# Altium schematic sheet Sensing_Control_3phase_sheet1.SchDoc
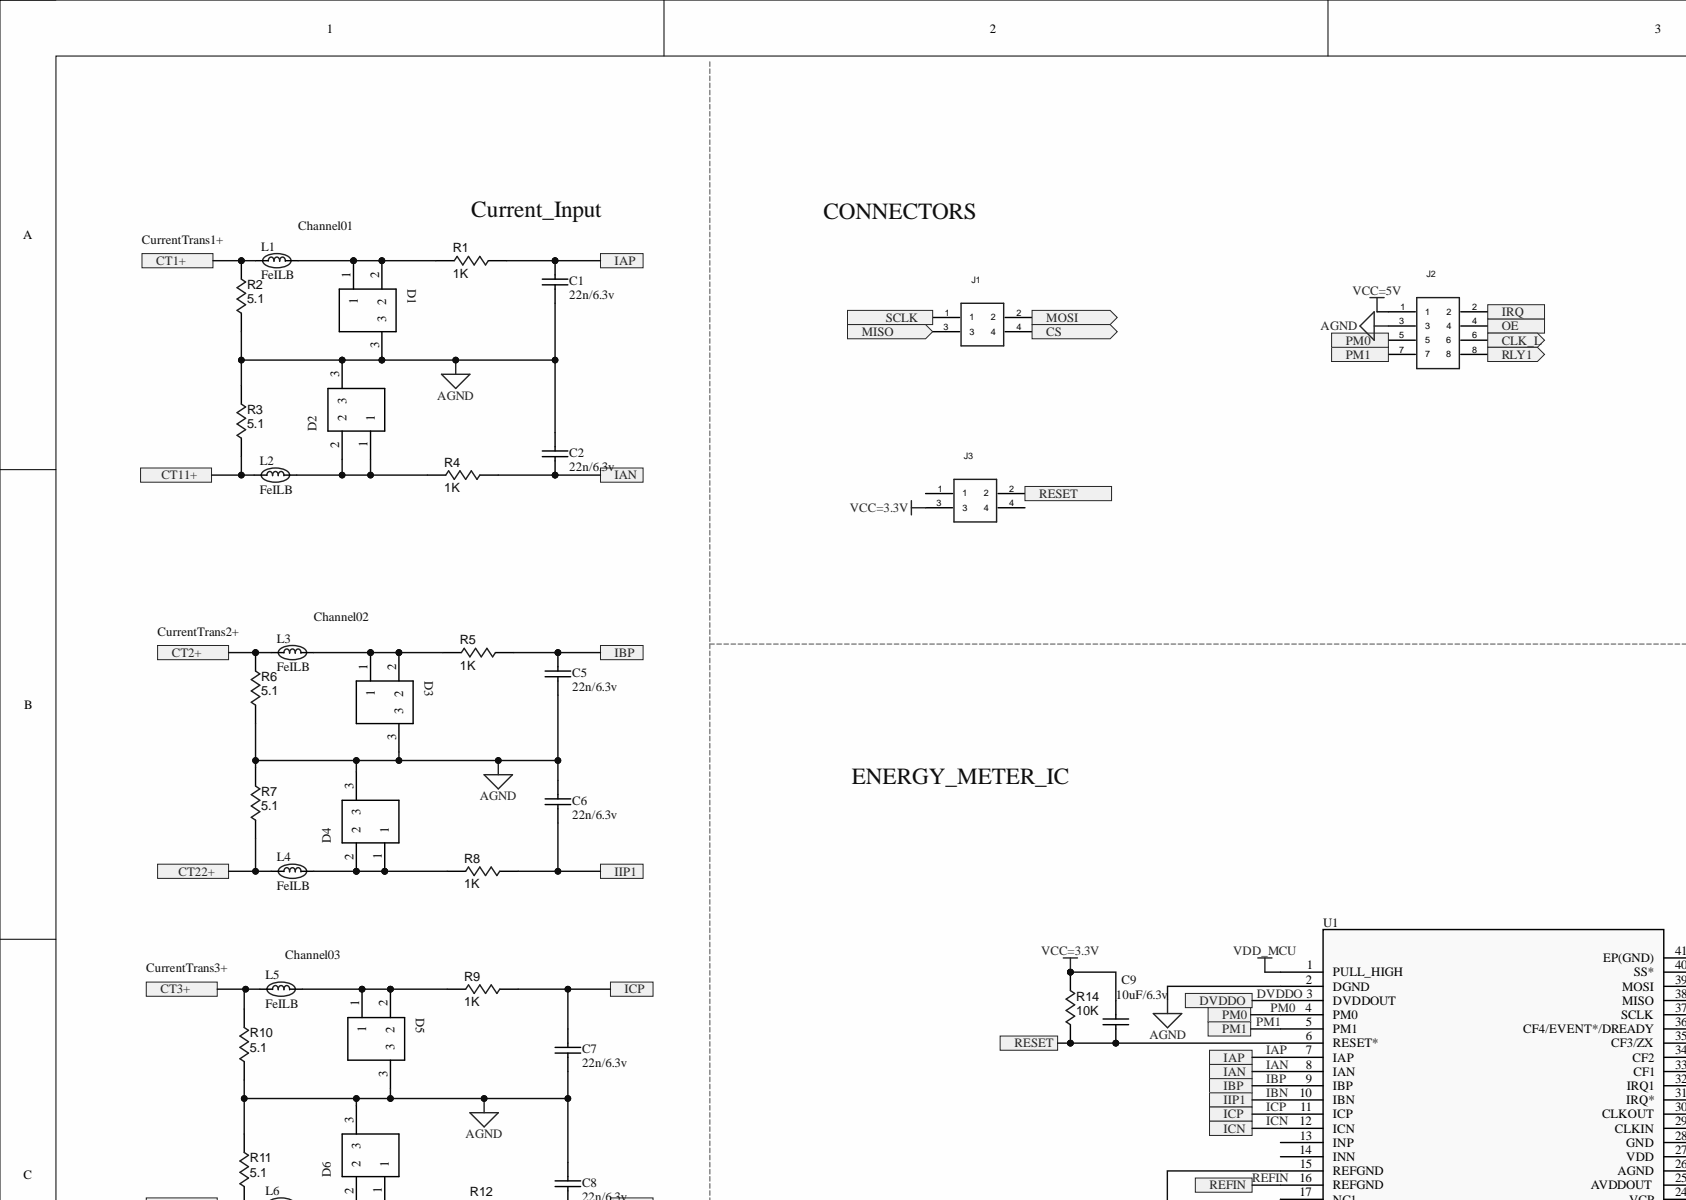
[diagram p0: Sensing_Control_3phase_sheet1.SchDoc, top left]
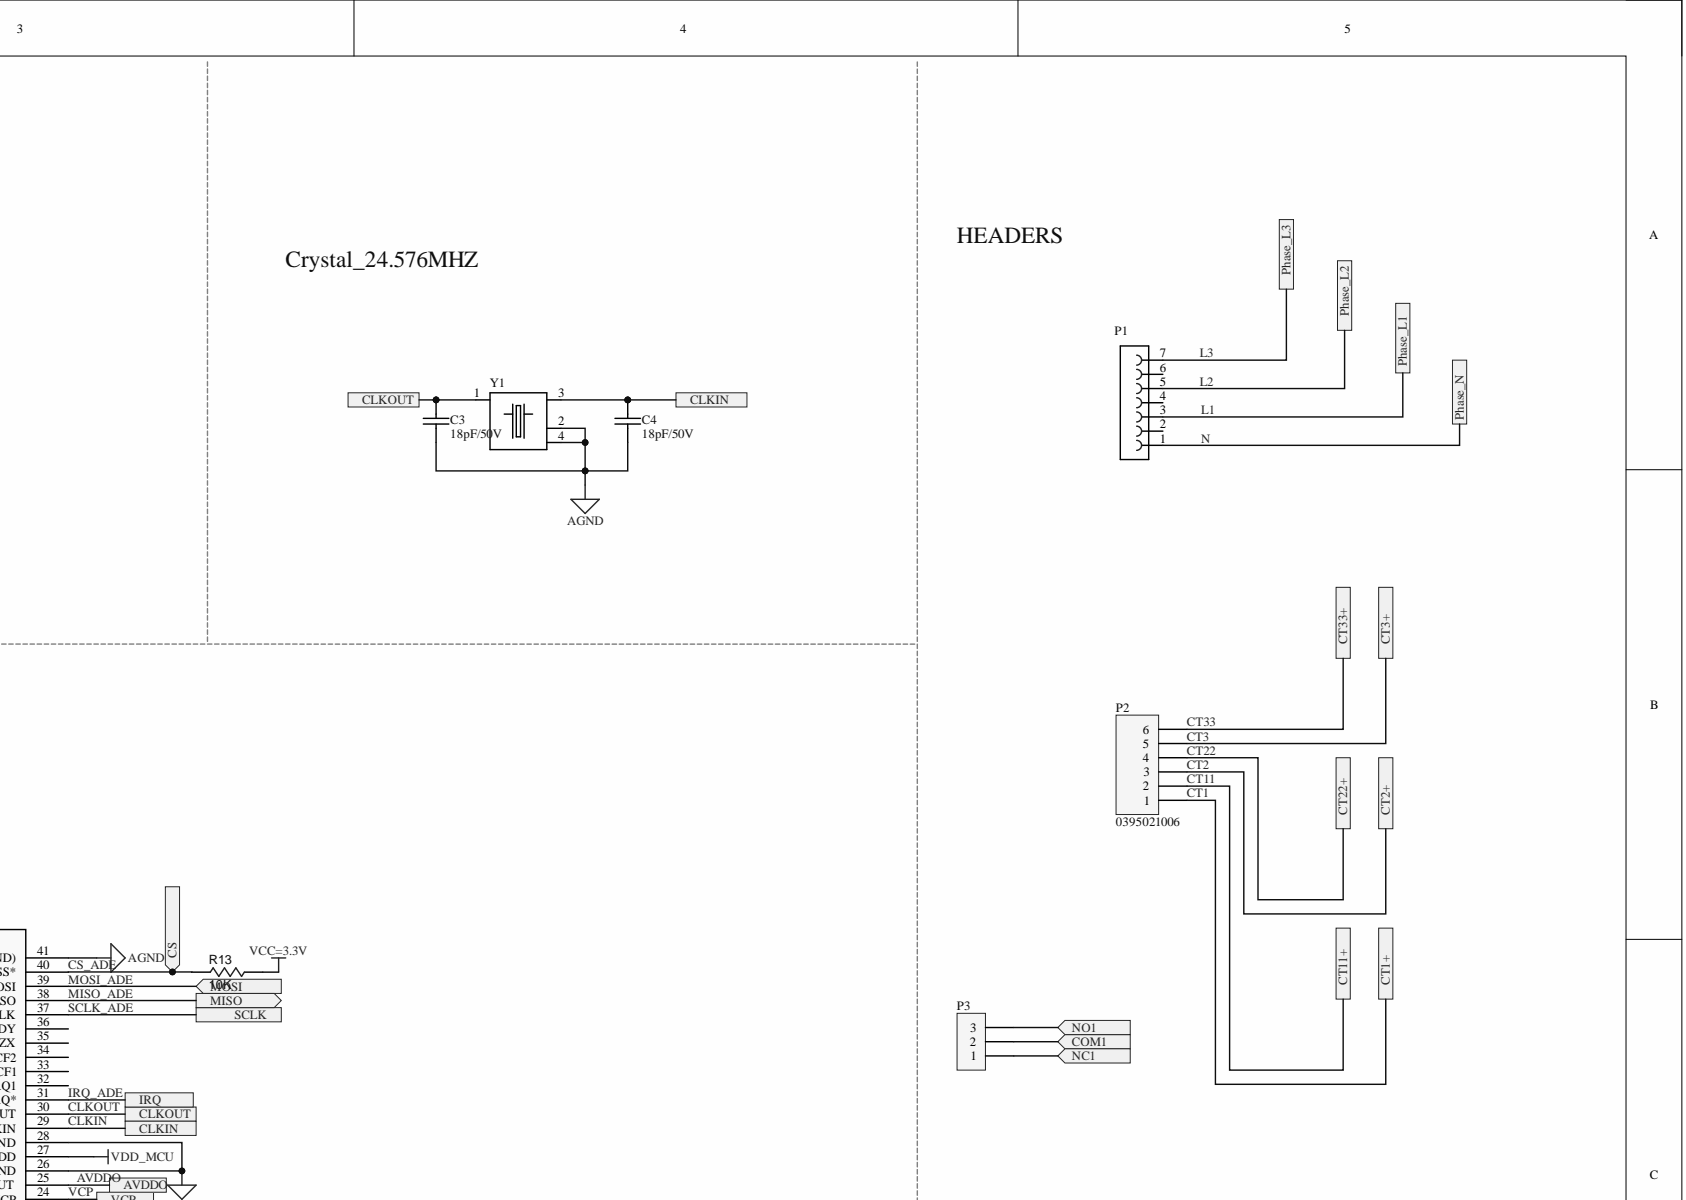
[diagram p1: Sensing_Control_3phase_sheet1.SchDoc, top right]
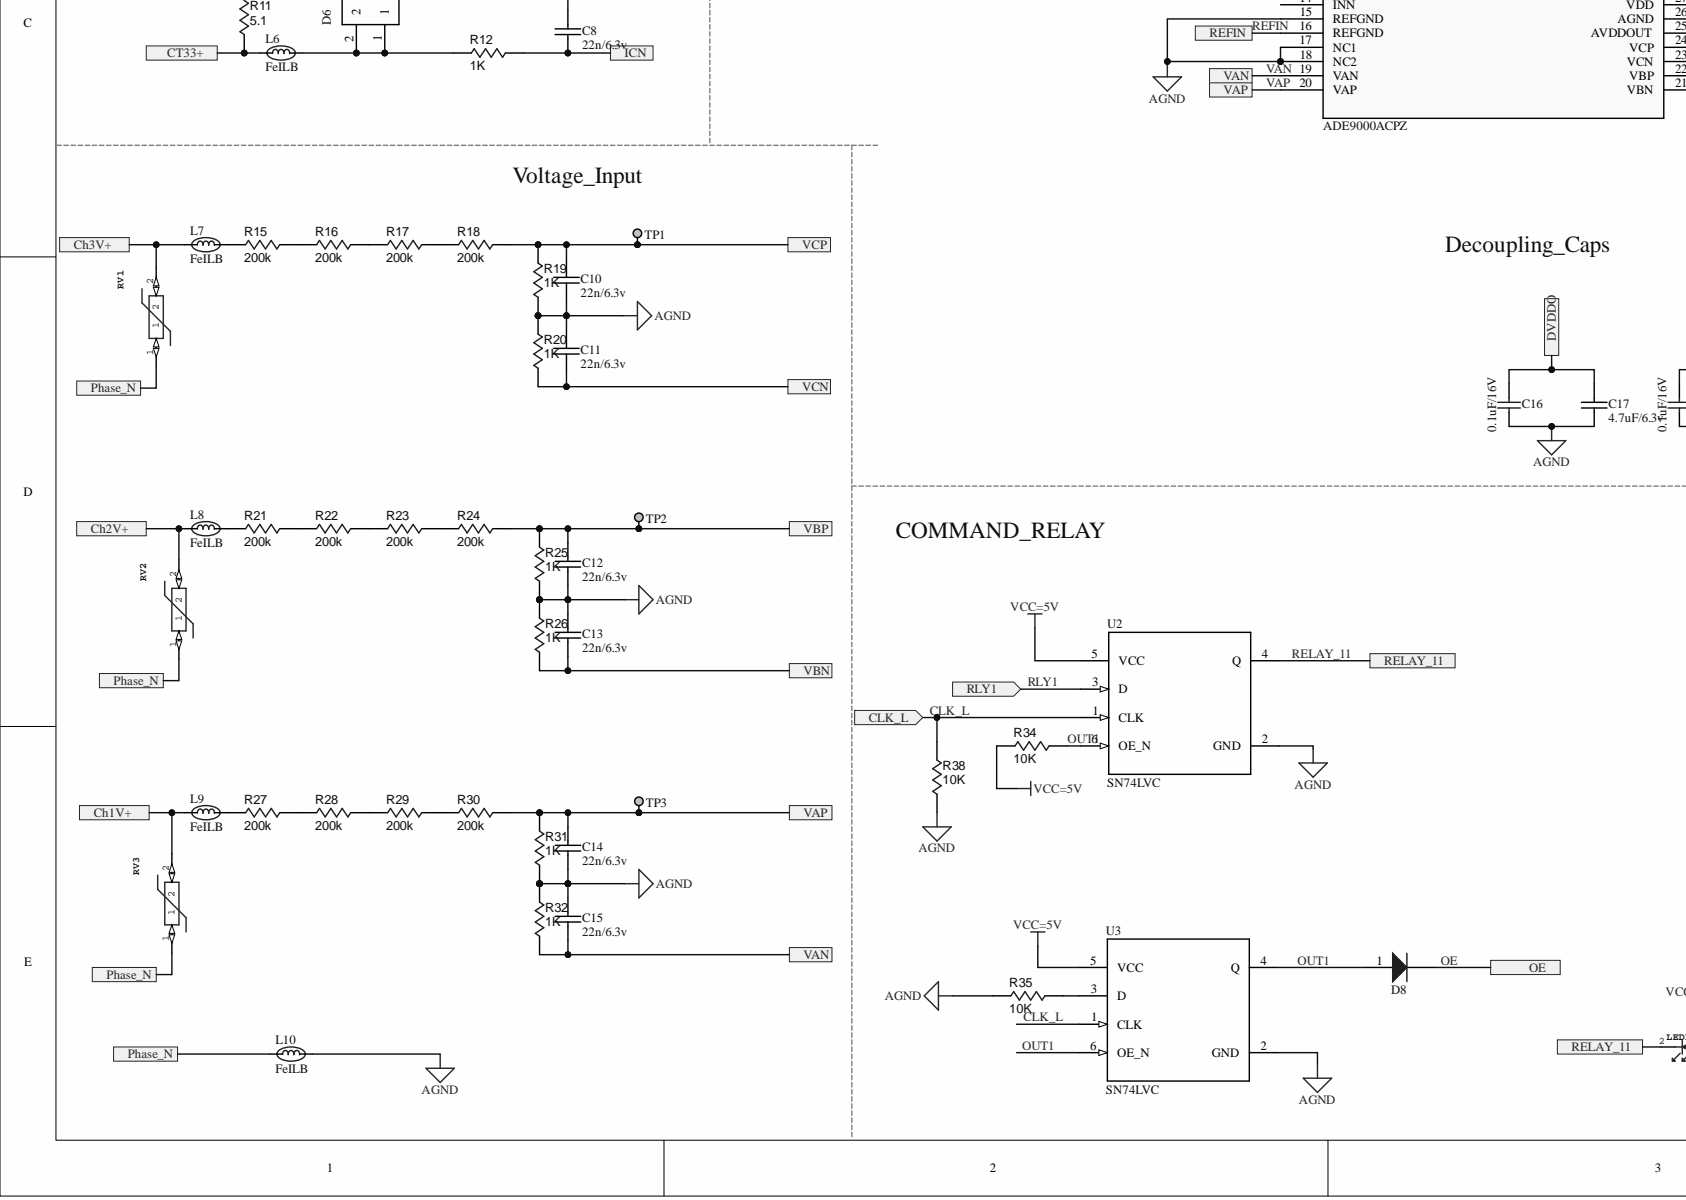
[diagram p2: Sensing_Control_3phase_sheet1.SchDoc, bottom left]
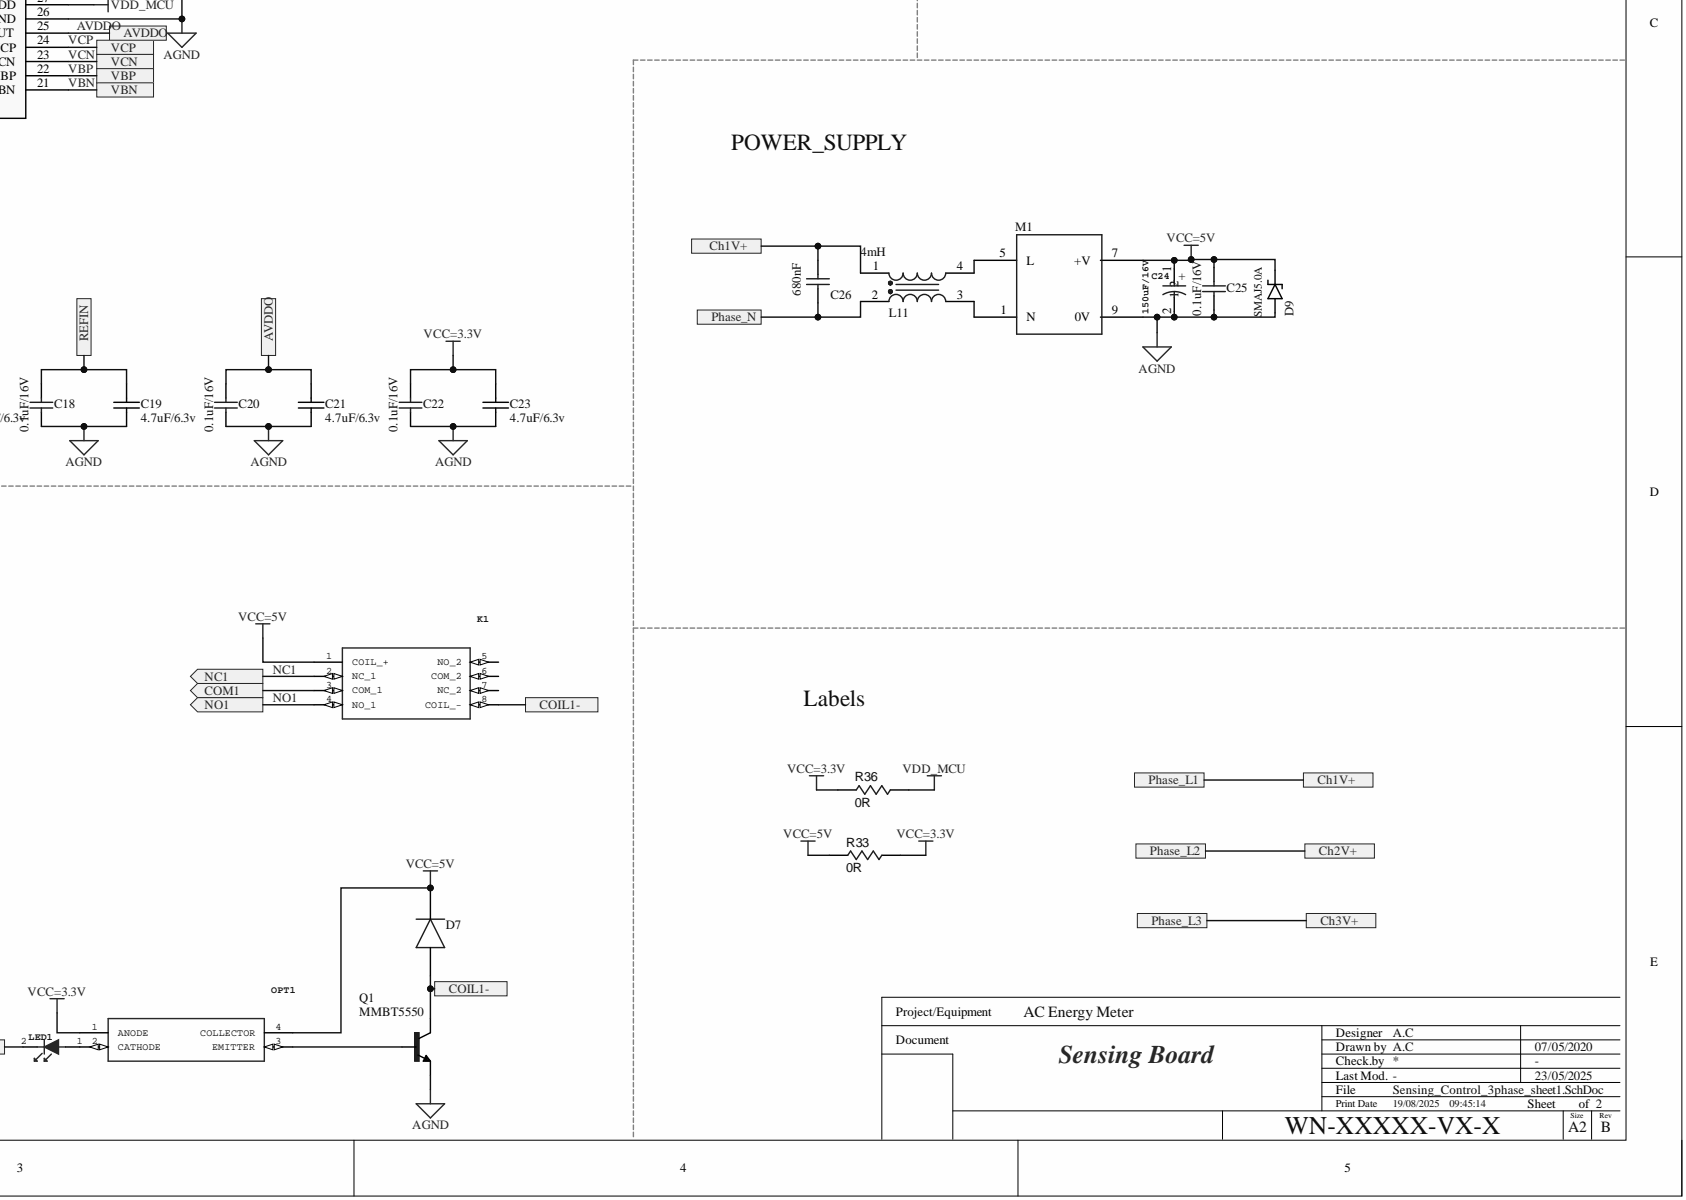
[diagram p3: Sensing_Control_3phase_sheet1.SchDoc, bottom right]

## Parts
C1  22n/6.3v  [0603C-TBD]
C2  22n/6.3v  [0603C-TBD]
C3  18pF/50V  [0603C-TBD]
C4  18pF/50V  [0603C-TBD]
C5  22n/6.3v  [0603C-TBD]
C6  22n/6.3v  [0603C-TBD]
C7  22n/6.3v  [0603C-TBD]
C8  22n/6.3v  [0603C-TBD]
C9  10uF/6.3v  [0603C-TBD]
C10  22n/6.3v  [0603C-TBD]
C11  22n/6.3v  [0603C-TBD]
C12  22n/6.3v  [0603C-TBD]
C13  22n/6.3v  [0603C-TBD]
C14  22n/6.3v  [0603C-TBD]
C15  22n/6.3v  [0603C-TBD]
C16  0.1uF/16V  [0603C-TBD]
C17  4.7uF/6.3v  [0603C-TBD]
C18  0.1uF/16V  [0603C-TBD]
C19  4.7uF/6.3v  [0603C-TBD]
C20  0.1uF/16V  [0603C-TBD]
C21  4.7uF/6.3v  [0603C-TBD]
C22  0.1uF/16V  [0603C-TBD]
C23  4.7uF/6.3v  [0603C-TBD]
C24  150uF/16V  [CAPAE660X800N]
C25  0.1uF/16V  [0603C-TBD]
C26  680nF  [WCAP-FTX2_L18W10H16_CS]
D1  BAV99W-7-F  [BAV99W-7-F]  (value not drawn)
D2  BAV99W-7-F  [BAV99W-7-F]  (value not drawn)
D3  BAV99W-7-F  [BAV99W-7-F]  (value not drawn)
D4  BAV99W-7-F  [BAV99W-7-F]  (value not drawn)
D5  BAV99W-7-F  [BAV99W-7-F]  (value not drawn)
D6  BAV99W-7-F  [BAV99W-7-F]  (value not drawn)
D7  1N4148W-13-F  [SOD3716X135N]  (value not drawn)
D8  TS4148C RZG  [LEDM1608X80]  (value not drawn)
D9  SMAJ5.0A  [DIOM5436X245N]
J1  SFMC-102-X1-XX-D  [SFMC-102-X1-XX-D - 570]  (value not drawn)
J2  SFMC-104-X1-XX-D  [SFMC-104-X1-XX-D - 570]  (value not drawn)
J3  SFMC-102-X1-XX-D  [SFMC-102-X1-XX-D - 570]  (value not drawn)
K1  Low Signal Relays - PCBSource:  Datasheet  [UD25NUN]  (value not drawn)
L1  FeILB  [0603C]
L2  FeILB  [0603C]
L3  FeILB  [0603C]
L4  FeILB  [FerriteBead-0603]
L5  FeILB  [FerriteBead-0603]
L6  FeILB  [FerriteBead-0603]
L7  FeILB  [FerriteBead-0603]
L8  FeILB  [FerriteBead-0603]
L9  FeILB  [FerriteBead-0603]
L10  FeILB  [FerriteBead-0603]
L11  4mH  [WE-CMB_Type XS_L15.8W7.8H18]
LED1  APHD1608LCGCK  [LED-1608]  (value not drawn)
M1  Myrra47152  [Myrra 47121]  (value not drawn)
OPT1  HCPL-817-000E  [DIP762W60P254L460H420Q4N]  (value not drawn)
P1  0395021007  [Molex_395021007 1X7]  (value not drawn)
P2  0395021006  [Molex_0395021006 1X6_2240X920X]
P3  0395021003  [Molex_0395021003 1X3_1190X920X]  (value not drawn)
Q1  MMBT5550  [SOT23]
R1  1K  [0603C-TBD]
R2  5.1  [0603C-TBD]
R3  5.1  [0603C-TBD]
R4  1K  [0603C-TBD]
R5  1K  [0603C-TBD]
R6  5.1  [0603C-TBD]
R7  5.1  [0603C-TBD]
R8  1K  [0603C-TBD]
R9  1K  [0603C-TBD]
R10  5.1  [0603C-TBD]
R11  5.1  [0603C-TBD]
R12  1K  [0603C-TBD]
R13  10K  [0603C-TBD]
R14  10K  [0603C-TBD]
R15  200k  [RES-5025-2010]
R16  200k  [RES-5025-2010]
R17  200k  [RES-5025-2010]
R18  200k  [RES-5025-2010]
R19  1K  [0603C-TBD]
R20  1K  [0603C-TBD]
R21  200k  [RES-5025-2010]
R22  200k  [RES-5025-2010]
R23  200k  [RES-5025-2010]
R24  200k  [RES-5025-2010]
R25  1K  [0603C-TBD]
R26  1K  [0603C-TBD]
R27  200k  [RES-5025-2010]
R28  200k  [RES-5025-2010]
R29  200k  [RES-5025-2010]
R30  200k  [RES-5025-2010]
R31  1K  [0603C-TBD]
R32  1K  [0603C-TBD]
R33  0R  [0603C-TBD]
R34  10K  [0603C-TBD]
R35  10K  [0603C-TBD]
R36  0R  [0603C-TBD]
R38  10K  [0603C-TBD]
RV1  EPCOS - B72207S0271K101 - VARISTOR,21.0J,275VAC   Source:  Datasheet  [B72207S0271K101]  (value not drawn)
RV2  EPCOS - B72207S0271K101 - VARISTOR,21.0J,275VAC   Source:  Datasheet  [B72207S0271K101]  (value not drawn)
RV3  EPCOS - B72207S0271K101 - VARISTOR,21.0J,275VAC   Source:  Datasheet  [B72207S0271K101]  (value not drawn)
TP1  TESTPOINT  [TESTPOINT]  (value not drawn)
TP2  TESTPOINT  [TESTPOINT]  (value not drawn)
TP3  TESTPOINT  [TESTPOINT]  (value not drawn)
U1  ADE9000ACPZ  [QFN50P600X600X100-41N]
U2  SN74LVC  [DCK0006A_N]
U3  SN74LVC  [DCK0006A_N]
Y1  ECS-245.7-12-33Q-JES-TR  [OSCCC320X250X80N]  (value not drawn)

## Ports
AVDDO, Ch1V+, Ch2V+, Ch3V+, CLK_L, CLKIN, CLKOUT, COIL1-, COM1, CS, CT1+, CT2+, CT3+, CT11+, CT22+, CT33+, DVDDO, IAN, IAP, IBP, ICN, ICP, IIP1, IRQ, MISO, MOSI, NC1, NO1, OE, Phase_L1, Phase_L2, Phase_L3, Phase_N, PM0, PM1, REFIN, RELAY_11, RESET, RLY1, SCLK, VAN, VAP, VBN, VBP, VCN, VCP

## Nets
AGND: C1.2 C2.1 C3.2 C4.2 C5.2 C6.1 C7.2 C8.1 C10.2 C11.1 C12.2 C13.1 C14.2 C15.1 C16.2 C17.2 C18.2 C19.2 C20.2 C21.2 C22.2 C23.2 C24.2 C25.2 D1.3 D2.3 D3.3 D4.3 D5.3 D6.3 D9.A J2.3 L10.2 M1.9 Q1.2 R2.1 R3.2 R6.1 R7.2 R10.1 R11.2 R19.1 R20.2 R25.1 R26.2 R31.1 R32.2 R35.2 R38.2 U1.2 U1.15 U1.17 U1.18 U1.26 U1.28 U1.41 U2.2 U3.2 Y1.2 Y1.4
VCC=3.3V: C9.1 C22.1 C23.1 J3.3 OPT1.1 R13.2 R14.2 R33.2 R36.1
VCC=5V: C24.1 C25.1 D7.1 D9.C J2.1 K1.1 M1.7 OPT1.4 R33.1 R34.2 U2.5 U3.5
VDD_MCU: R36.2 U1.1 U1.27
AVDDO: C20.1 C21.1 U1.25 ; port AVDDO
CLK_L: J2.6 R38.1 U2.1 U3.1 ; port CLK_L
CLKIN: C4.1 U1.29 Y1.3 ; port CLKIN
CLKOUT: C3.1 U1.30 Y1.1 ; port CLKOUT
COIL1-: D7.2 K1.8 Q1.3 ; port COIL1-
COM1: K1.3 P3.2 ; port COM1
CS_ADE: J1.4 R13.1 U1.40 ; port CS
CT1: L1.1 P2.1 R2.2 ; port CT1+
CT2: L3.1 P2.3 R6.2 ; port CT2+
CT3: L5.1 P2.5 R10.2 ; port CT3+
CT11: L2.1 P2.2 R3.1 ; port CT11+
CT22: L4.1 P2.4 R7.1 ; port CT22+
CT33: L6.1 P2.6 R11.1 ; port CT33+
DVDDO: C16.1 C17.1 U1.3 ; port DVDDO
IAN: C2.2 R4.2 U1.8 ; port IAN
IAP: C1.1 R1.2 U1.7 ; port IAP
IBN: C6.2 R8.2 U1.10 ; port IIP1
IBP: C5.1 R5.2 U1.9 ; port IBP
ICN: C8.2 R12.2 U1.12 ; port ICN
ICP: C7.1 R9.2 U1.11 ; port ICP
IRQ_ADE: J2.2 U1.31 ; port IRQ
L1: C26.2 L9.1 L11.1 P1.3 RV3.2 ; port Ch1V+, port Phase_L1
L2: L8.1 P1.5 RV2.2 ; port Ch2V+, port Phase_L2
L3: L7.1 P1.7 RV1.2 ; port Ch3V+, port Phase_L3
MISO_ADE: J1.3 U1.38 ; port MISO
MOSI_ADE: J1.2 U1.39 ; port MOSI
N: C26.1 L10.1 L11.2 P1.1 RV1.1 RV2.1 RV3.1 ; port Phase_N
NC1: K1.2 P3.1 ; port NC1
NO1: K1.4 P3.3 ; port NO1
OE: D8.2 J2.4 ; port OE
OUT1: D8.1 R34.1 U2.6 U3.4 U3.6
PM0: J2.5 U1.4 ; port PM0
PM1: J2.7 U1.5 ; port PM1
REFIN: C18.1 C19.1 U1.16 ; port REFIN
RELAY_11: LED1.2 U2.4 ; port RELAY_11
RESET: C9.2 J3.2 R14.1 U1.6 ; port RESET
RLY1: J2.8 U2.3 ; port RLY1
SCLK_ADE: J1.1 U1.37 ; port SCLK
VAN: C15.2 R32.1 U1.19 ; port VAN
VAP: C14.1 R30.2 R31.2 TP3.1 U1.20 ; port VAP
VBN: C13.2 R26.1 U1.21 ; port VBN
VBP: C12.1 R24.2 R25.2 TP2.1 U1.22 ; port VBP
VCN: C11.2 R20.1 U1.23 ; port VCN
VCP: C10.1 R18.2 R19.2 TP1.1 U1.24 ; port VCP
NetD1_1: D1.1 D1.2 L1.2 R1.1
NetD2_1: D2.1 D2.2 L2.2 R4.1
NetD3_1: D3.1 D3.2 L3.2 R5.1
NetD4_1: D4.1 D4.2 L4.2 R8.1
NetD5_1: D5.1 D5.2 L5.2 R9.1
NetD6_1: D6.1 D6.2 L6.2 R12.1
NetJ3_1: J3.1
NetJ3_4: J3.4
NetK1_5: K1.5
NetK1_6: K1.6
NetK1_7: K1.7
NetL7_2: L7.2 R15.1
NetL8_2: L8.2 R21.1
NetL9_2: L9.2 R27.1
NetL11_3: L11.3 M1.1
NetL11_4: L11.4 M1.5
NetLED1_1: LED1.1 OPT1.2
NetOPT1_3: OPT1.3 Q1.1
NetP1_2: P1.2
NetP1_4: P1.4
NetP1_6: P1.6
NetR15_2: R15.2 R16.1
NetR16_2: R16.2 R17.1
NetR17_2: R17.2 R18.1
NetR21_2: R21.2 R22.1
NetR22_2: R22.2 R23.1
NetR23_2: R23.2 R24.1
NetR27_2: R27.2 R28.1
NetR28_2: R28.2 R29.1
NetR29_2: R29.2 R30.1
NetR35_1: R35.1 U3.3
NetU1_13: U1.13
NetU1_14: U1.14
NetU1_32: U1.32
NetU1_33: U1.33
NetU1_34: U1.34
NetU1_35: U1.35
NetU1_36: U1.36

## Library data of the parts
C1 C2 C5-C8 C10-C15 = 0603C: CAPACITOR CERAMIC 0603 0.022u , 6.3V, X7R.; Manufacturer: Murata; Manufacturer Part Number: GRM188R70J223KA01D; Capacitance: 22nF; Dielectric: X7R; Tolerance: 10%; Category: Ceramic Capacitors; Component Kind: Standard; Library Name: Schematic_library.SchLib; Supplier 1: Digi-Key; Supplier Part Number 1: 490-14809-1-ND; Voltage Rating: 6.3V
C3 C4 = 0603C: CAPACITOR 0603 CERAMIC  50V, 10%; Manufacturer: KEMET; Manufacturer Part Number: C0603C180K5GACTU; Capacitance: 18pF; Case Code (Metric): 1608; Component Kind: Standard; Library Name: Schematic_library.SchLib; Supplier 1: Digi-Key; Supplier Part Number 1: 399-7866-1-ND; Tolerance: 10%; Voltage Rating: 50V
C9 = 0603C: CAPACITOR 0603 CERAMIC  6.3V, X5R, 20%; Manufacturer: Samsung; Manufacturer Part Number: CL10A106MQ8NNNC; Capacitance: 10uF; Case Code (Metric): 1608; Category: Ceramic Capacitors; Component Kind: Standard; Dielectric: X5R; Library Name: Schematic_library.SchLib; Supplier 1: Digi-Key; Supplier Part Number 1: 1276-1119-1-ND; Tolerance: 20%; Voltage: 6.3V
C16 C18 C20 C22 C25 = Cap: CAPACITOR 0603 CERAMIC; Manufacturer: Samsung; Manufacturer Part Number: CL10F104ZO8NNNC; Capacitance: 100nF; Value: 100nF; Voltage Rating: 16V; Case Code (Metric): 1608; Category: Ceramic Capacitors; Component Kind: Standard; Library Name: Schematic_library.SchLib; Revision: July-2002: Re-released for DXP Platform.; Signal Integrity: Cap; Simulation: CAP; Supplier 1: Digi-Key; Supplier Part Number 1: 1276-1258-1-ND
C17 C19 C21 C23 = 0603C: CAPACITOR 0603 CERAMIC  6.3V, 20%; Manufacturer: Samsung; Manufacturer Part Number: CL10A475KQ8NNNC; Capacitance: 4.7uF; Dielectric: X5R; Case Code (Metric): 1608; Category: Ceramic Capacitors; Component Kind: Standard; Library Name: Schematic_library.SchLib; Supplier 1: Digi-Key; Supplier Part Number 1: 1276-1045-1-ND; Tolerance: 20%; Voltage: 6.3V
C24 = Cap_Pol_UUD1C151MCL1GS: Condensateurs électrolytiques aluminium 16V 150µF Radial, boîtier métallique - SMD 2000 heures à 105°C; Manufacturer_Name: Panasonic; Allied_Number: 70068101; DeviceSetName: EEEFK1C151XP; GateName_1: G$1; Allied_Price-Stock: https://www.alliedelec.com/panasonic-eeefk1c151xp/70068101/; Component Kind: Standard; ComponentLink1Description: ref2; ComponentLink1URL: https://www.digikey.fr/product-detail/fr/w-rth-elektronik/865060345006/732-8523-1-ND/5728480; ComponentLink2Description: ref3; ComponentLink2URL: https://www.digikey.fr/product-detail/fr/nichicon/UWZ1C151MCL1GS/493-9926-1-ND/3963223; Height: 8mm; Library Name: Schematic_library.SchLib; LibraryName: EEEFK1C151XP; Manufacturer_Part_Number: EEEFK1C151XP; Package: CAPAE660X800N; RS_Part_Number: 7478920; RS_Price-Stock: https://uk.rs-online.com/web/p/products/7478920; Supplier 1: Digi-Key; Supplier Part Number 1: 493-2263-1-ND; SymbolName_1: EEEFK1C151XP
C26 = 890324025045CS: WCAP-FTX2 Film Capacitor, THT, L18 x W10 x H16mm, 680nF, 275V   Aletrnate Part R46KI368045P1M; Manufacturer: Wurth Elektronik; Capacitance Tolerance: +/-10%; Dissipation Factor @1kHz: 0.1%; Dissipation Factor @10kHz: 0.7%; Insulation Resistance: 10000Ω x F; Pitch: 15mm; Rate of Voltage Rise: 230V/µs; Technical Reference: MX2P015684K275ACPP45004; Capacitance: 680nF; Category: Film Capacitors; Component Kind: Standard; ComponentLink1Description: Website Link; ComponentLink1URL: https://www.we-online.com/redexpert/article/890324025045CS?ad; ComponentLink2Description: Datasheet Link; ComponentLink2URL: https://www.we-online.com/redexpert/spec/890324025045CS?ad; ComponentLink3Description: http://www.mouser.com/ds/2/212/KEM_F3094_R46_X2_275_110C_MIN-1104429.pdf?src-supplier=Mouser; ComponentLink3URL: http://www.mouser.com/ds/2/212/KEM_F3094_R46_X2_275_110C_MIN-1104429.pdf?src-supplier=Mouser; Height: 16mm; Length: 18mm; Library Name: Schematic_library.SchLib; Manufacturer Part Number: 890324025045CS; Match Code: WCAP-FTX2; Mount: Through Hole; Operating Temperature Max: 105°C; Operating Temperature Min: -40°C; Packaging: Carton; Rated Voltage (AC): 275V; Simulation: 890324025045CS_680nF; Width: 10mm
D1-D6 = BAV99W: DIODE ARRAY GP 75V 150MA SOT-323; Manufacturer: Diodes; Power Dissipation: 200mW; Average Rectified Current: 150mA; Case/Package: SOT-323; Component Kind: Standard; ComponentLink1Description: supplier; ComponentLink1URL: https://www.digikey.fr/product-detail/fr/diodes-incorporated/BAV99W-7-F/BAV99W-FDICT-ND/717837; Library Name: Schematic_library.SchLib; Manufacturer Part Number: BAV99W-7-F; Supplier 1: Digi-Key; Supplier Part Number 1: BAV99W-FDICT-ND; Voltage Rating (DC): 75V
D7 = 1N4148W-G3-08: Diodes - General Purpose, Power, Switching 100 Volt 150mA 4ns 500mA IFSM; Manufacturer: Vishay; Manufacturer Part Number: 1N4148W-E3-08; Forward Voltage: 1.2V; Max Forward Surge Current (Ifsm): 2A; Max Power Dissipation: 350mW; Average Rectified Current: 150mA; Case/Package: SOD-123-2; Component Kind: Standard; ComponentLink2Description: Supplier; ComponentLink2URL: https://www.digikey.com/product-detail/en/1N4148W-13-F/1N4148W-13FDICT-ND/2242774?utm_campaign=buynow&WT.z_cid=ref_octopart_dkc_buynow&utm_medium=aggregator&curr=usd&site=us&utm_source=octopart; Forward Current: 150mA; Mount: Surface Mount; Number of Pins: 2; Supplier 1: Digi-Key; Supplier Part Number 1: 1N4148W-E3-08DKR-ND
D8 = Diode TS4148C: DIODE GEN PURP 75V 150MA 0603; Manufacturer: Taiwan Semiconductor; Forward Voltage: 1.25V; Case/Package: SMD/SMT; Component Kind: Standard; Manufacturer Part Number: TS4148CRZG; Supplier 1: Digi-Key; Supplier Part Number 1: TS4148CRZGCT-ND
D9 = SMAJ5.0A: 9.2V Clamp 43.5A Ipp Tvs Diode Surface Mount SMA (DO-214AC); MF: Littelfuse Inc.; MP: SMAJ58A; Availability: Unavailable; Component Kind: Standard; Library Name: Schematic_library.SchLib; Package: DO-214AC-214 STMicroelectronics; Price: None; SnapEDA_Link: https://www.snapeda.com/parts/SMAJ58A/Littelfuse%20Inc./view-part/?ref=snap; Supplier 1: Digi-Key; Supplier Part Number 1: SMAJ5.0ABCT-ND
J1 J3 = SFMC-102-X1-XX-D: Socket Strip, Cuttable, Double Row, 0.050"x0.050" Pitch; MANUFACTURER: SAMTEC; PCB DECAL: FW-02-02-L-D-560-150; System: Connector; Component Kind: Standard; Library Name: Schematic_library.SchLib; CREATED: JUNE 03,  2014 - WENDY XIN
  J1: Supplier 1: Samtec
  J3: Supplier 1: SAMTEC
J2 = SFMC-104-X1-XX-D: Socket Strip, Cuttable, Double Row, 0.050"x0.050" Pitch; MANUFACTURER: SAMTEC; PCB DECAL: FW-04-02-L-D-560-150; System: Connector; Component Kind: Standard; Library Name: Schematic_library.SchLib; Supplier 1: SAMTEC; CREATED: JUNE 03,  2014 - WENDY XIN
K1 = UD2-5NUN: RELAY GEN PURPOSE DPDT 1A 5VDC; Manufacturer: NEC; Component Kind: Standard; ComponentLink1Description: Supplier; ComponentLink1URL: https://www.digikey.fr/product-detail/fr/kemet/UD2-5SNU/399-17359-5-ND/5502168; ComponentLink2Description: Datasheet; ComponentLink2URL: http://www.kemet.com/Lists/ProductCatalog/Attachments/519/KEM_R7005_UC2_UD2.pdf; Manufacturer Part Number: UD2-5NUN; Supplier 1: Digi-Key
L1-L10 = FerrBeads/ILBB: Ind Chi bead 1.5K, 20%, 0603; Manufacturer: Murata; Manufacturer Part Number: BLM18HE152SN1D; Current Rating: 500mA; Impedance: 1.5kR; Test Frequency: 100MHz; Tolerance: 25%; Case Code (Metric): 1608; Category: Ferrite Beads and Chips; Component Kind: Standard; Library Name: Schematic_library.SchLib; Supplier 1: Digi-Key; Supplier Part Number 1: 490-5216-1-ND
L11 = 744821240: WE-CMB Common Mode Power Line Choke, THT, Type XS, 4mH, 1.5A, 140mOhm; Manufacturer: Wurth Elektronik; Case/Size Code: Type XS; Insulation Test Voltage: 1500VAC; Material: MnZn; Rated Voltage (AC): 250V; Category: Common Mode Chokes; Component Kind: Standard; ComponentLink1Description: Website Link; ComponentLink1URL: https://www.we-online.com/redexpert/article/744821240?ad; ComponentLink2Description: Datasheet Link; ComponentLink2URL: https://www.we-online.com/redexpert/spec/744821240?ad; DC Resistance Max: 140mOhm; Height: 18mm; Inductance: 4mH; Length: 15.8mm; Library Name: Schematic_library.SchLib; Manufacturer Part Number: 744821240; Match Code: WE-CMB; Mount: Through Hole; Operating Temperature Max: 125°C; Operating Temperature Min: -40°C; Packaging: Carton; Rated Current: 1.5A; Simulation: XS_744821240_4m; Width: 7.8mm
LED1 = LED-1608: LED GREEN 0603, 2mA, 1.9V; Manufacturer: Kingbright; Case Code (Metric): 1608; Case/Package: 0603; Category: LEDs; Color: Green; Component Kind: Standard; Forward Voltage: 1.9V; Manufacturer Part Number: APHD1608LCGCK; Supplier 1: Digi-Key; Supplier Part Number 1: 754-2031-1-ND; Test Current: 2mA
M1 = Myrra47121: electronic transformer, Switching power supply; Component Kind: Standard; ComponentLink1Description: Supplier1(47152); ComponentLink1URL: https://www.soselectronic.com/products/myrra/47152-101297; ComponentLink2Description: Supplier2(47121); ComponentLink2URL: https://www.soselectronic.com/products/myrra/47121-101290; Library Name: Schematic_library.SchLib; Supplier 1: Sos electronic; Supplier 2: Farnell; Supplier Part Number 1: 47152; Supplier Part Number 2: 1825779
OPT1 = LTV-817-B: Transistor Output Optocouplers 5000 Vrms 50mA; Manufacturer: Broadcom Avago; Approvals: UL,CSA,VDE; Collector Emitter Saturation Voltage: 200mV; Collector Emitter Voltage (VCEO): 35V; Current Transfer Ratio: 600%; Fall Time: 18000ns; Forward Voltage: 1.4V; Isolation Voltage: 5kV; Case/Package: PDIP; Category: Optocouplers; Component Kind: Standard; ComponentLink1Description: Supplier; ComponentLink1URL: https://www.mouser.fr/ProductDetail/Lite-On/LTV-817C?qs=WAWaI72GHKzVL8VAYU18sA==; ComponentLink2Description: Datasheet; ComponentLink2URL: https://www.mouser.fr/datasheet/2/239/LTV-8X7%20series%20201610%20-1218079.pdf; Forward Current: 50mA; Manufacturer Part Number: HCPL-817-000E; Supplier 1: Digi-Key; Supplier Part Number 1: 516-1585-5-ND
P1 = Header 7 Pos-3.5mm_1: 7 Position Terminal Block Header, Male Pins, Shrouded (4 Side) 0.138" (3.50mm) 90°, Right Angle - Through Hole; Manufacturer: Molex; Contact Resistance: 8mR; Number of Contacts: 7; Pitch: 0.138inch; Voltage: 300V; Category: Terminal Blocks; Color: Black; Component Kind: Standard; ComponentLink1Description: datasheet; ComponentLink1URL: http://www.farnell.com/datasheets/1734841.pdf; ComponentLink2Description: supplier female; ComponentLink2URL: https://www.mouser.fr/ProductDetail/Amphenol-Anytek/TJ0611530000G?qs=%2fha2pyFaduhkoA9HRM%252bOn511Q5kGyCqHG62sJd2aSYStPgaDJ6SuRA%3d%3d; ComponentLink3Description: Specs; ComponentLink3URL: https://4donline.ihs.com/images/VipMasterEMD/EMD/WEID/WEIDD00075/WEIDD00075-84.pdf; Depth: 9.2mm; Library Name: Schematic_library.SchLib; Manufacturer Part Number: 0395021007; Mount: Through Hole; Number of Positions Per Level: 7; Number of Rows: 1; Orientation: Right Angle; Series: Eurostyle™ ESE 39502; Supplier 1: Mouser; Supplier Part Number 1: 538-39502-1007; Supplier Unit Price 1: $2.27; Termination: Solder
P2 = Header 6: PCB plug-in connector, male header,  THT solder 3.50 mm,  6poles, 90; Manufacturer: Molex; Number of Contacts: 6; Pitch: 3.5mm; Category: Terminal Blocks; Color: Black; Component Kind: Standard; Depth: 9.2mm; Gender: Male; Length: 22.39mm; Library Name: Schematic_library.SchLib; Mount: Through Hole; Number of Positions Per Level: 6; Number of Rows: 1; Orientation: Right Angle; Supplier 1: Mouser; Supplier Part Number 1: 538-39502-1006; Supplier Unit Price 1: $1.64
P3 = Header_Male_03_1x03: 3 Position Terminal Block Header, Male Pins, Shrouded (4 Side) 0.138" (3.50mm) 90°, Right Angle Through Hole; Manufacturer: Molex; Number of Circuits: 3; Category: Headers and Wire Housings; Color: Black; Component Kind: Standard; Depth: 9.2mm; Flammability Rating: UL94 V-0; Length: 11.89mm; Library Name: Schematic_library.SchLib; Manufacturer Part Number: 0395021003; Mount: Through Hole; Number of Rows: 1; Orientation: Right Angle; Supplier 1: Mouser; Supplier Part Number 1: 538-39502-1003; Supplier Unit Price 1: $0.85
Q1 = MMBT5550: DRDC3105 is an integrated solid-state DC relay drive - SOT-23; Manufacturer: ON Semiconductor; Manufacturer Part Number: MMBT5550LT1G; Current Rating: 600mA; Polarity: NPN; Case/Package: SOT-23-3; Component Kind: Standard; ComponentLink1Description: datasheet; ComponentLink1URL: https://www.diodes.com/assets/Datasheets/DRDC3105.pdf; ComponentLink2Description: Datasheet2; ComponentLink2URL: https://www.mouser.fr/ProductDetail/ON-Semiconductor-Fairchild/MMBT5550?qs=UMEuL5FsraDBO%2FdfCyI1mw%3D%3D; Supplier 1: Digi-Key; Supplier Part Number 1: MMBT5550LT1GOSCT-ND
R1 R4 R5 R8 R9 R12 R19 R20 R25 R26 R31 R32 = RES: Gen Purp Chip, R0603 0.1W 1%; Manufacturer: Yageo; Manufacturer Part Number: RT0603BRD071KL; Voltage Rating (DC): 50V; Case Code (Metric): 1608; Category: Chip SMD Resistors; Component Kind: Standard; Composition: Thin Film; Library Name: Schematic_library.SchLib; Supplier 1: Digi-Key; Supplier Part Number 1: YAG1237CT-ND
R2 R3 R6 R7 R10 R11 = RES: Gen Purp Chip, R0603 0.1W 1%; Manufacturer: Stackpole Electronics; Manufacturer Part Number: RMCF0603FT5R10; Component Kind: Standard; Library Name: Schematic_library.SchLib; Mount: Surface Mount; Supplier 1: Digi-Key; Supplier Part Number 1: RMCF0603FT5R10CT-ND; Tolerance: 1%
R13 R14 R34 R35 R38 = RES: RES SMD 10K OHM 5% 1/10W 0603; Manufacturer: Panasonic; Manufacturer Part Number: ERJ-3GEYJ103V; Max Power Dissipation: 100mW; Resistance: 10kR; Temperature Coefficient: 200ppm/°C; Voltage Rating: 75V; Case Code (Metric): 1608; Category: Chip SMD Resistors; Component Kind: Standard; Composition: Thick Film; Library Name: Schematic_library.SchLib; Supplier 1: Digi-Key; Supplier Part Number 1: P10KGCT-ND
R15-R18 R21-R24 R27-R30 = RES_2010: RES SMD 200K OHM 1% 3/4W 2010 RC2010FK-07200KL; Manufacturer: Stackpole Electronics; Max Power Dissipation: 750mW; Resistance: 200kR; Temperature Coefficient: 100ppm/°C; Case/Package: 2010; Category: Chip SMD Resistors; Component Kind: Standard; Library Name: Schematic_library.SchLib; Supplier 1: Digi-Key; Supplier Part Number 1: P200KDACT-ND; Tolerance: 1%
R33 R36 = RES: Gen Purp Chip, R0603  0R, 0.1W 1%; Manufacturer: Yageo; Manufacturer Part Number: RC0603JR-070RL; Temperature Coefficient: 200ppm/°C; Voltage Rating: 50V; Case Code (Metric): 1608; Category: Chip SMD Resistors; Component Kind: Standard; Composition: Thick Film; Library Name: Schematic_library.SchLib; Tolerance: 1%
RV1-RV3 = MOV_B72207S0271K101: Varistors 275volts 1200A  7 mm; Manufacturer: TDK EPCOS; Capacitance: 95pF; Clamping Current: 10A; Clamping Voltage: 710V; Max Surge Current: 1.2kA; Max Voltage Rating (AC): 275V; Varistor Voltage: 430V; Case/Package: Radial; Category: Varistors; Component Kind: Standard; ComponentLink1Description: datasheet; ComponentLink1URL: https://www.tdk-electronics.tdk.com/inf/70/db/var/SIOV_Leaded_StandarD.pdf; Diameter: 7mm; Library Name: Schematic_library.SchLib; Manufacturer Part Number: B72207S0271K101; Supplier 1: Digi-Key; Supplier Part Number 1: 495-1408-ND
TP1-TP3 = TESTPOINT: TESTPOINT SCOPE GRABBER; Manufacturer: Keystone Electronics; Manufacturer Part Number: 5010; Reel: TH; Component Kind: Standard; Library Name: vh_altium_library.intLib; Supplier 1: Digi-Key; Supplier Part Number 1: 5010K-ND
U1 = ADE9000ACPZ: Manufacturer: Analog Devices; Number of Channels: 7; Category: Analog to Digital Converters (ADCs); Component Kind: Standard; ComponentLink1Description: https://www.analog.com/media/en/technical-documentation/data-sheets/ADE9000.pdf?src-supplier=Digi-Key; ComponentLink1URL: https://www.analog.com/media/en/technical-documentation/data-sheets/ADE9000.pdf?src-supplier=Digi-Key; Description: ADE9000ACPZ; Library Name: Schematic_library.SchLib; Manufacturer Part Number: ADE9000ACPZ; Number of Pins: 40; Supplier 1: Digi-Key; Supplier Part Number 1: ADE9000ACPZ-ND; Supplier Unit Price 1: $8.63
U2 U3 = SN74LVC: Single D-Type Flip-Flop With 3-State Output; Manufacturer: Texas Instruments; Manufacturer Part Number: SN74LVC1G374DCKR; Clock Edge Trigger Type: Positive Edge; Frequency: 175MHz; High Level Output Current: -32mA; Logic Function: D-Type,Latch; Max Supply Voltage: 5.5V; Quiescent Current: 10uA; Category: Logic Gates; Component Kind: Standard; ComponentLink1Description: Datasheet; ComponentLink1URL: http://www.ti.com/lit/ds/symlink/sn74lvc1g374.pdf; Mount: Surface Mount; Number of Pins: 6; Supplier 1: Digi-Key; Supplier Part Number 1: 296-18777-1-ND
Y1 = ECS-245.7-12-33Q-JES-TR: CRYSTAL 24.576MHZ 12PF SMD; Manufacturer: ECS International; ESR (Equivalent Series Resistance): 40R; Frequency Stability: 50ppm; Frequency Tolerance: 20ppm; Case/Package: SMD/SMT; Category: Crystals; Component Kind: Standard; ComponentLink1Description: http://www.ecsxtal.com/store/pdf/partnumber4.pdf?src-supplier=Digi-Key; ComponentLink1URL: http://www.ecsxtal.com/store/pdf/partnumber4.pdf?src-supplier=Digi-Key; Frequency: 24.576MHz; Library Name: Schematic_library.SchLib; Load Capacitance: 12pF; Manufacturer Part Number: ECS-245.7-12-33Q-JES-TR; Supplier 1: Digi-Key; Supplier Part Number 1: XC2178CT-ND
